# Altium schematic sheet 05 SERVO_FAN.SchDoc
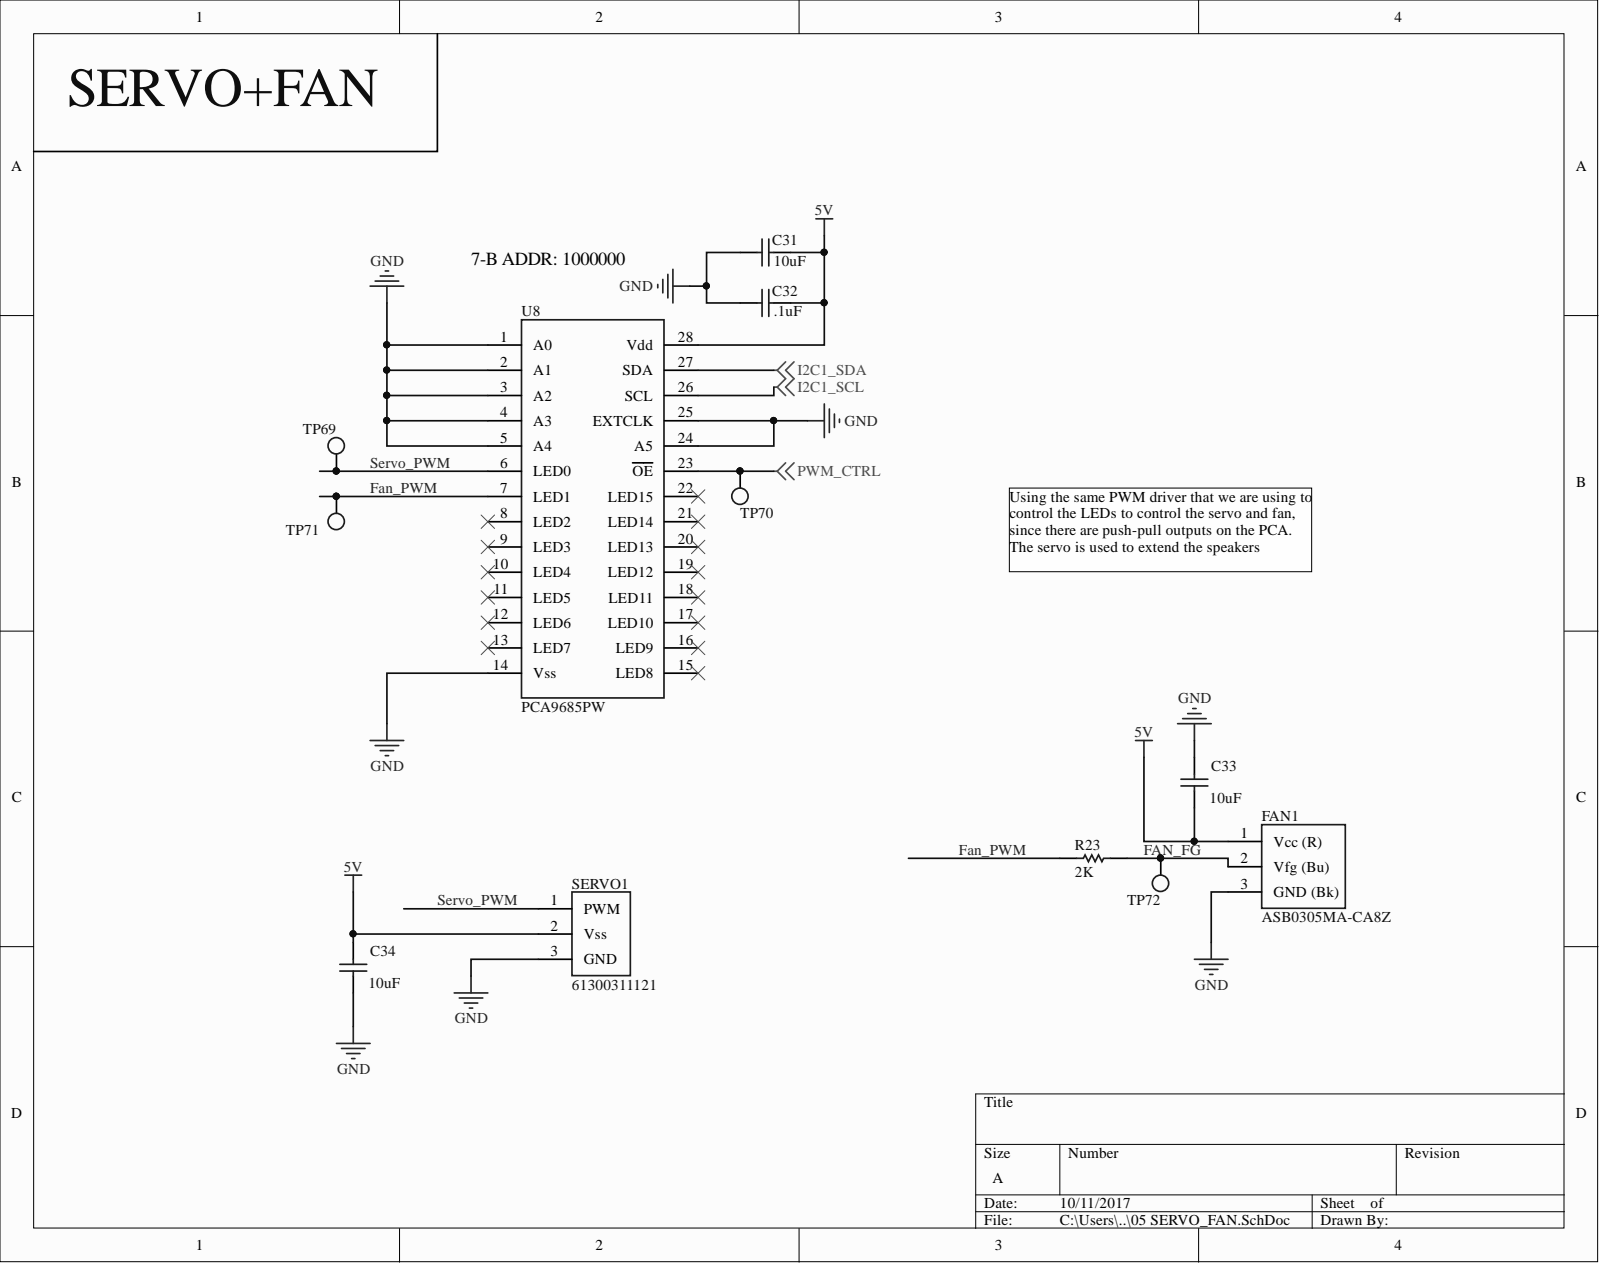
[diagram p0: 05 SERVO_FAN.SchDoc]

## Parts
C31  value 10uF  Capacitance: 10uF  [CAPC2012X135N]  (hidden comment: CAP_0805_10u_16V)
C32  value .1uF  [CAPC2012X135N]  (hidden comment: CAP_0805_.1u_250V)
C33  value 10uF  Capacitance: 10uF  [CAPC2012X135N]  (hidden comment: CAP_0805_10u_16V)
C34  value 10uF  Capacitance: 10uF  [CAPC2012X135N]  (hidden comment: CAP_0805_10u_16V)
FAN1  ASB0305MA-CA8Z  [FAN_HEADER]
R23  RES_0805_2K  [RES0805]  (value not drawn)
SERVO1  61300311121  [SERVO_HEADER]
TP69  TP  [TestPoint_1206]
TP70  TP  [TestPoint_1206]
TP71  TP  [TestPoint_1206]
TP72  TP  [TestPoint_1206]
U8  PCA9685PW  [TSSOP28]

## Nets
5V: C31.2 C32.2 C33.1 C34.2 FAN1.1 SERVO1.2 U8.28(Vdd)
GND: C31.1 C32.1 C33.2 C34.1 FAN1.3 SERVO1.3 U8.1(A0) U8.2(A1) U8.3(A2) U8.4(A3) U8.5(A4) U8.14(Vss) U8.24(A5) U8.25(EXTCLK)
I2C1_SCL: U8.26(SCL)
I2C1_SDA: U8.27(SDA)
PWM_CTRL: TP70.1 U8.23(OE)
FAN_FG: FAN1.2 R23.2 TP72.1
Fan_PWM: R23.1 TP71.1 U8.7(LED1)
Servo_PWM: SERVO1.1 TP69.1 U8.6(LED0)

Not connected: U8 8(LED2) 9(LED3) 10(LED4) 11(LED5) 12(LED6) 13(LED7) 15(LED8) 16(LED9) 17(LED10) 18(LED11) 19(LED12) 20(LED13) 21(LED14) 22(LED15)

## Library data of the parts
C31 C33 C34 = CAP_0805_10u_16V: Cap Ceramic 10uF 16V X5R 10% SMD 0805 85C T/R; Manufacturer: Johanson Dielectrics; Manufacturer Part Number: 160R15X106KV4E; Case/Package: 0805; Case Code (Imperial): 0805; Case Code (Metric): 2012; Component Kind: Standard; ComponentLink1Description: https://datasheet.ciiva.com/26948/getdatasheetpartid-132904-26948555.pdf; ComponentLink1URL: https://datasheet.ciiva.com/26948/getdatasheetpartid-132904-26948555.pdf; Depth: 1.27mm; Dielectric: X5R; Height: 1.27mm; Length: 2.03mm; Max Operating Temperature: 85°C; Min Operating Temperature: -55°C; Mount: Surface Mount; Number of Pins: 2; Package Quantity: 2000; Packaging: Tape and Reel; Pricing 1: 1=0.55, 10=0.387, 100=0.2552, 500=0.189, 1000=0.1607 (USD); Radiation Hardening: No; REACH SVHC: No SVHC; Stock 1: 36636; Supplier 1: Digi-Key; Supplier Part Number 1: 709-1229-1-ND; Termination: SMD/SMT; Thickness: 0.06inch; Tolerance: 10%; Voltage Rating: 16V; Voltage Rating (DC): 16V; Weight: 0.000194oz; Width: 1.27mm; Library Name: schlib_truman.SchLib
C32 = CAP_0805_.1u_250V: Cap Ceramic 0.1uF 250V X7T 10% SMD 0805 125C Plastic T/R; Manufacturer: TDK; Manufacturer Part Number: C2012X7T2E104K125AE; Capacitance: 100nF; Case/Package: 0805; Case Code (Imperial): 0805; Case Code (Metric): 2012; Component Kind: Standard; ComponentLink1Description: https://datasheet.ciiva.com/24219/c2012x7t2e104k125ae-265154-24219519.pdf; ComponentLink1URL: https://datasheet.ciiva.com/24219/c2012x7t2e104k125ae-265154-24219519.pdf; Composition: Ceramic; Depth: 1.25mm; Dielectric: X7T; Height: 1.25mm; Length: 2mm; Max Operating Temperature: 125°C; Min Operating Temperature: -55°C; Package Quantity: 1; Packaging: Tape and Reel; Pricing 1: 1=0.37, 10=0.25, 100=0.1419, 500=0.1002, 1000=0.0877 (USD); REACH SVHC: No SVHC; Stock 1: 30376; Supplier 1: Digi-Key; Supplier Part Number 1: 445-8883-1-ND; Termination: SMD/SMT; Thickness: 0.049inch; Tolerance: 10%; Voltage Rating: 250V; Voltage Rating (DC): 250V; Weight: 0.000194oz; Width: 0.05inch; Library Name: schlib_truman.SchLib
FAN1 = ASB0305MA-CA8Z: FAN AXIAL 30X10MM 5VDC WIRE; Manufacturer: Delta Design; Airflow: 3.4CFM; Bearing Type: Sleeve; Current Rating: 110mA; Max Power Dissipation: 550mW; Noise: 23dBA; RPM: 9000RPM; Component Kind: Standard; ComponentLink1Description: //media.digikey.com/pdf/Data%20Sheets/Delta%20PDFs/ASB0305MA-CA8Z_Spec.pdf; ComponentLink1URL: //media.digikey.com/pdf/Data%20Sheets/Delta%20PDFs/ASB0305MA-CA8Z_Spec.pdf; Manufacturer Part Number: ASB0305MA-CA8Z; Max Operating Temperature: 158°C; Min Operating Temperature: 14°C; Package Quantity: 720; Pricing 1: 1=6.96, 10=6.38, 50=5.365 (USD); Stock 1: 98; Supplier 1: Digi-Key; Supplier Part Number 1: 603-1847-ND; Termination: 3 Wire Leads; Weight: 0.015lb; Width: 10mm; Library Name: schlib_truman.SchLib
R23 = RES_0805_2K: RES SMD 2K OHM 5% 1/8W 0805; Manufacturer: Yageo; Manufacturer Part Number: RC0805JR-072KL; Max Power Dissipation: 125mW; Resistance: 2KR; Temperature Coefficient: 100ppm/°C; Voltage Rating: 150V; : 2K; Case/Package: 0805; Case Code (Imperial): 0805; Case Code (Metric): 2012; Component Kind: Standard; ComponentLink1Description: https://datasheet.ciiva.com/46395/444164-46395141.pdf; ComponentLink1URL: https://datasheet.ciiva.com/46395/444164-46395141.pdf; ComponentLink2Description: https://datasheet.ciiva.com/2456/pyu-r-int-thick-7-2456104.pdf; ComponentLink2URL: https://datasheet.ciiva.com/2456/pyu-r-int-thick-7-2456104.pdf; ComponentLink3Description: https://datasheet.ciiva.com/2456/pyu-r-int-thick-7-2456105.pdf; ComponentLink3URL: https://datasheet.ciiva.com/2456/pyu-r-int-thick-7-2456105.pdf; ComponentLink4Description: https://datasheet.ciiva.com/2456/pyu-r-int-thick-7-2456106.pdf; ComponentLink4URL: https://datasheet.ciiva.com/2456/pyu-r-int-thick-7-2456106.pdf; ComponentLink5Description: https://datasheet.ciiva.com/12666/rc0805-12666941.pdf; ComponentLink5URL: https://datasheet.ciiva.com/12666/rc0805-12666941.pdf; Composition: Thick Film; Contact Plating: Tin,Lead,Matte; Depth: 1.25mm; Height: 0.024inch; Lead Free: Lead Free; Length: 2mm; Max Operating Temperature: 155°C; Military Standard: Not; Min Operating Temperature: -55°C; Number of Pins: 2; Number of Terminations: 2; Package Quantity: 1; Packaging: Tape and Reel; Power Rating: 125mW; Pricing 1: 1=0.1, 10=0.016, 25=0.0116, 50=0.009, 100=0.0066, 250=0.005, 500=0.004, 1000=0.003 (USD); Radiation Hardening: No; REACH SVHC: No SVHC; Stock 1: 297667; Supplier 1: Digi-Key; Supplier Part Number 1: 311-2.0KARCT-ND; Termination: SMD/SMT; Tolerance: 5%; Voltage Rating (DC): 150V; Width: 0.049inch; Library Name: schlib_truman.SchLib
SERVO1 = Servo_Header: Board-To-Board Connector, Vertical, WR-PHD Series, Through Hole, Header, 3 Contacts, 2.54 mm; Manufacturer: Wurth Electronics; Connector Type: Male Pin; Gender: Male; Number of Contacts: 3; Pitch: 0.1inch; Case/Package: 100; Colour: Black; Component Kind: Standard; ComponentLink1Description: http://www.farnell.com/cad/1737268.pdf; ComponentLink1URL: http://www.farnell.com/cad/1737268.pdf; Contact Material: Copper; Contact Mating Length: 0.236inch; Contact Plating: Gold; Lead Free: Lead Free; Manufacturer Part Number: 61300311121; Mount: Through Hole; Number of Positions: 3; Number of Rows: 1; Packaging: Bulk; Pricing 2: 1=0.13, 10=0.106, 25=0.088, 50=0.074, 100=0.067, 1000=0.061 (USD); REACH SVHC: Unknown; Stock 2: 24543; Supplier 1: Digi-Key; Supplier 2: Digi-Key; Supplier Part Number 1: 900-00008-ND; Supplier Part Number 2: 732-5316-ND; Termination: Solder; Library Name: schlib_truman.SchLib
TP69-TP72 = TP: Testpoint_1206; Manufacturer: TE Connectivity; Manufacturer Part Number: 1625854-4; Resistance: 0mR; Case/Package: 1206; Colour: Natural; Component Kind: Standard; ComponentLink1Description: https://datasheet.ciiva.com/16499/1625854-4-16499923.pdf; ComponentLink1URL: https://datasheet.ciiva.com/16499/1625854-4-16499923.pdf; ComponentLink2Description: https://datasheet.ciiva.com/6439/eng-ds-1773266-a-6439173.pdf; ComponentLink2URL: https://datasheet.ciiva.com/6439/eng-ds-1773266-a-6439173.pdf; ComponentLink3Description: https://datasheet.ciiva.com/6439/eng-ds-1773266-a-6439161.pdf; ComponentLink3URL: https://datasheet.ciiva.com/6439/eng-ds-1773266-a-6439161.pdf; ComponentLink4Description: https://datasheet.ciiva.com/36535/eng-ds-1773266-a-36535790.pdf; ComponentLink4URL: https://datasheet.ciiva.com/36535/eng-ds-1773266-a-36535790.pdf; Depth: 1.6mm; Lead Free: Lead Free; Length: 3.2mm; Max Operating Temperature: 125°C; Military Standard: Not; Min Operating Temperature: -55°C; Package Quantity: 2000; Packaging: Tape and Reel; Pricing 1: 1=0.28, 10=0.261, 25=0.224, 50=0.1902, 100=0.1828, 250=0.1641, 500=0.1567, 1000=0.1306 (USD); Radiation Hardening: No; Stock 1: 23359; Supplier 1: Digi-Key; Supplier Part Number 1: A106146CT-ND; Library Name: schlib_truman.SchLib
U8 = PCA9685PW: IC LED DRIVER RGBA 28-TSSOP; Manufacturer: NXP Semiconductors; Manufacturer Part Number: PCA9685PW,118; Frequency: 1MHz; High Level Output Current: 10mA; Low Level Output Current: 400A; Max Supply Voltage: 5.5V; Min Supply Voltage: 2.3V; Nominal Supply Current: 10A; Number of Outputs: 16; Output Current per Channel: 25mA; Power Dissipation: 400W; Case/Package: TSSOP; Component Kind: Standard; ComponentLink1Description: https://datasheet.ciiva.com/1947/pca9685-1947062.pdf; ComponentLink1URL: https://datasheet.ciiva.com/1947/pca9685-1947062.pdf; ComponentLink2Description: https://datasheet.ciiva.com/15134/getdatasheetpartid-103066-15134201.pdf; ComponentLink2URL: https://datasheet.ciiva.com/15134/getdatasheetpartid-103066-15134201.pdf; ComponentLink3Description: https://datasheet.ciiva.com/8125/pca9685-8125357.pdf; ComponentLink3URL: https://datasheet.ciiva.com/8125/pca9685-8125357.pdf; Lead Free: Lead Free; Max Operating Temperature: 85°C; Min Operating Temperature: -40°C; Mount: Surface Mount; Number of Pins: 28; Output Voltage: 5.5V; Package Quantity: 2500; Packaging: Tape and Reel; Pricing 1: 1=2.31, 10=2.074, 100=1.6674, 500=1.3699, 1000=1.1351 (USD); Radiation Hardening: No; Stock 1: 2599; Supplier 1: Digi-Key; Supplier Part Number 1: 568-11925-1-ND; Library Name: schlib_truman.SchLib
